# Altium schematic sheet uPre V2.SchDoc("uPre V2")
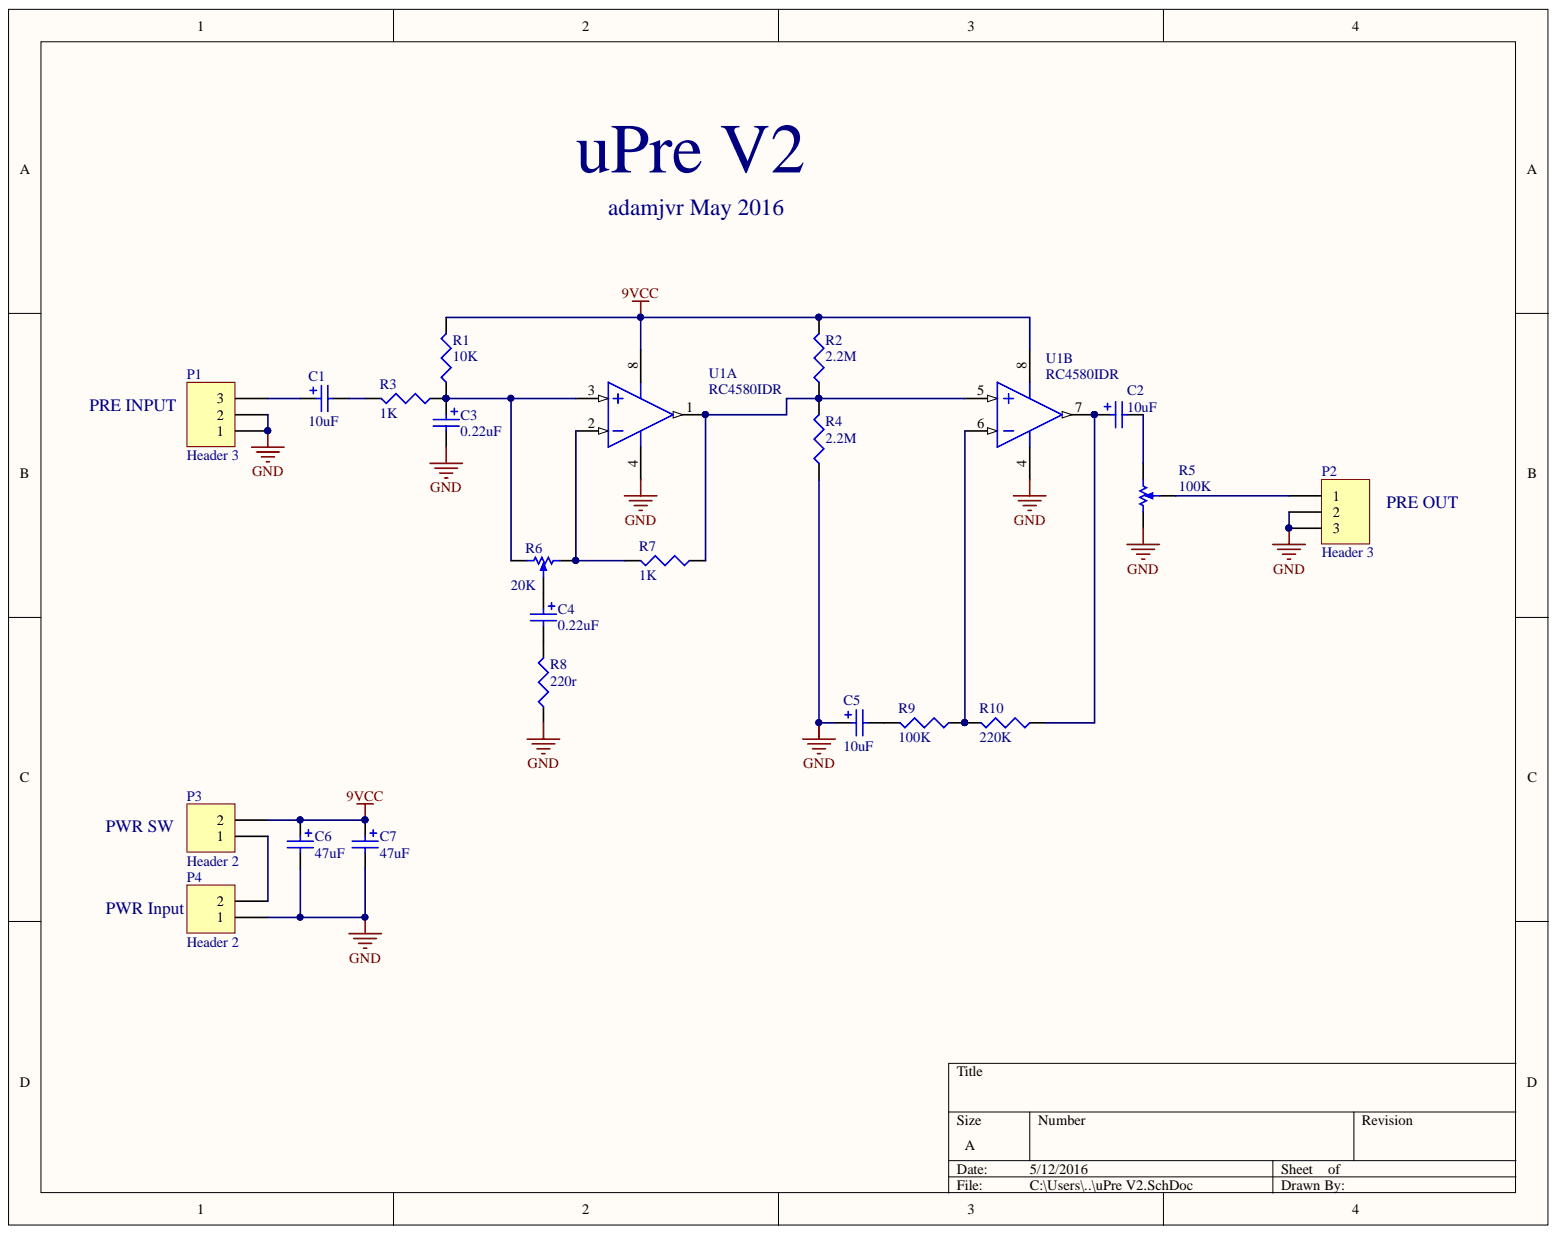
[diagram p0: uPre V2.SchDoc]

## Parts
C1  10uF  [4X6mmCAP]
C2  10uF  [4X6mmCAP]
C3  0.22uF  [4X6mmCAP]
C4  0.22uF  [4X6mmCAP]
C5  10uF  [4X6mmCAP]
C6  47uF  [5X12.5mmCAP - BLUE]
C7  47uF  [5X12.5mmCAP - BLUE]
P1  Header 3  [HDR1X3]
P2  Header 3  [HDR1X3]
P3  Header 2  [HDR1X2]
P4  Header 2  [HDR1X2]
R1  10K  [R0805]
R2  2.2M  [R0805]
R3  1K  [R0805]
R4  2.2M  [R0805]
R5  100K  [BOURNS_PTD90SERIES_POT]
R6  20K  [BOURNS_PTD90SERIES_POT]
R7  1K  [R0805]
R8  220r  [R0805]
R9  100K  [R0805]
R10  220K  [R0805]
U1  RC4580IDR  [SOIC127P600X175-8N]  (parts A,B)

## Nets
9VCC: C6.1 C7.1 P3.2 R1.2 R2.2 U1.8
GND: C3.2 C5.1 C6.2 C7.2 P1.1 P1.2 P2.2 P2.3 P4.1 R4.1 R5.3 R8.1 U1.4
NetC1_1: C1.1 P1.3
NetC1_2: C1.2 R3.2
NetC2_1: C2.1 R10.1 U1.7
NetC2_2: C2.2 R5.1
NetC3_1: C3.1 R1.1 R3.1 R6.3 U1.3
NetC4_1: C4.1 R6.2
NetC4_2: C4.2 R8.2
NetC5_2: C5.2 R9.2
NetP2_1: P2.1 R5.2
NetP3_1: P3.1 P4.2
NetR2_1: R2.1 R4.2 R7.1 U1.1 U1.5
NetR6_1: R6.1 R7.2 U1.2
NetR9_1: R9.1 R10.2 U1.6

## Library data of the parts
C1 C2 C5 = UMW1C100MDD1TP: CAP ALUM 10UF 20% 16V RADIAL; MFR: Nichicon; Designer: Adam Vadala-Roth; Lead-Free ?: Yes; Pin Count #: 2; Type: aluminum capacitor throughhole; Component Kind: Standard; Cost: 0.08766; Datasheet: http://nichicon-us.com/english/products/pdfs/e-umw.pdf; Date Created: 10 May 2016; Height: 6mm; Last Modified: 10 May 2016; Library Name: AudioPartLib.SchLib; MFR #: UMW1C100MDD1TP; Mounting: Through hole; Status: Active; Tolerance: 20%; Value: 10uF; Voltage: 16V
C3 C4 = UMW1HR22MDD1TP: CAP ALUM 0.22UF 20% 50V RADIAL; MFR: Nichicon; Designer: Adam Vadala-Roth; Lead-Free ?: Yes; Pin Count #: 2; Type: aluminum capacitor throughhole; Component Kind: Standard; Cost: 0.08766; Datasheet: http://nichicon-us.com/english/products/pdfs/e-umw.pdf; Date Created: 11 May 2016; Height: 6mm; Last Modified: 11 May 2016; Library Name: AudioPartLib.SchLib; MFR #: UMW1HR22MDD1TP; Mounting: Through hole; Status: Active; Tolerance: 20%; Value: 0.22uF; Voltage: 50V
C6 C7 = UKA1E470MDD1TD: CAP ALUM 47UF 20% 25V RADIAL; MFR: Nichicon; Designer: Adam Vadala-Roth; Lead-Free ?: Yes; Pin Count #: 2; Type: Aluminum Capacitor Radial Through hole; Component Kind: Standard; Cost: 0.11360 @ 2000; Datasheet: http://nichicon-us.com/english/products/pdfs/e-ufg.pdf; Date Created: 11 May 2016; Height: 13mm; Last Modified: 11 May 2016; Library Name: AudioPartLib.SchLib; MFR #: UKA1E470MDD1TD; Mounting: Through Hole; Status: Active; Tolerance: 20%; Value: 47uF; Voltage: 25V
P1 P2 = Header 3: Header, 3-Pin; Component Kind: Standard; LatestRevisionDate: 17-Jul-2002; LatestRevisionNote: Re-released for DXP Platform.; Library Name: Miscellaneous Connectors.IntLib; Signal Integrity: Connector; Publisher: Altium Limited
P3 P4 = Header 2: Header, 2-Pin; Component Kind: Standard; LatestRevisionDate: 17-Jul-2002; LatestRevisionNote: Re-released for DXP Platform.; Library Name: Miscellaneous Connectors.IntLib; Signal Integrity: Connector; Publisher: Altium Limited
R1 = ERJ-6ENF1002V: RES SMD 10K OHM 1% 1/8W 0805; MFR: Panasonic-Electronic-Components; Designer: Adam Vadala-Roth; Lead-Free ?: Yes; Pin Count #: 2; Component Kind: Standard; Cost: 0.00729 @ 1000; Datasheet: http://industrial.panasonic.com/www-cgi/jvcr13pz.cgi?E+PZ+3+AOA0002+ERJ6ENF1002V+7+WW; Date Created: 8 May 2016; Height: 0.7mm; Last Modified: 8 May 2016; Library Name: AudioPartLib.SchLib; MFR #: ERJ-6ENF1002V; Mounting: SMT/SMD; Status: Active; Tolerance: 1%; Type: Chip Resistor 0805; Value: 10K; Voltage: 1/8W
R2 R4 = RC2012F225CS: RES SMD 2.2M OHM 1% 1/8W 0805; MFR: Samsung-Electro-Mechanics-America,-Inc.; Designer: Adam Vadala-Roth; Lead-Free ?: Yes; Pin Count #: 2; Component Kind: Standard; Cost: 0.00289 @ 5000; Datasheet: https://media.digikey.com/pdf/Data%20Sheets/Samsung%20PDFs/RC_Series_ds.pdf; Date Created: 10 May 2016; Height: 0.60mm; Last Modified: 10 May 2016; Library Name: AudioPartLib.SchLib; MFR #: RC2012F225CS; Mounting: SMT/SMD; Status: Active; Tolerance: 1%; Type: Chip Resistor 0805; Value: 2.2M; Voltage: 1/8W
R3 R7 = RC2012F102CS: RES SMD 1K OHM 1% 1/8W 0805; MFR: Samsung-Electro-Mechanics-America,-Inc.; Designer: Adam Vadala-Roth; Lead-Free ?: Yes; Pin Count #: 2; Component Kind: Standard; Cost: 0.00408	 @ 1000; Datasheet: https://media.digikey.com/pdf/Data%20Sheets/Samsung%20PDFs/RC_Series_ds.pdf; Date Created: 10 May 2016; Height: 0.6mm; Last Modified: 10 May 2016; Library Name: AudioPartLib.SchLib; MFR #: RC2012F102CS; Mounting: SMT/SMD; Status: Active; Tolerance: 1%; Type: Chip Resistor; Value: 1K; Voltage: 1/8W
R5 = PTD901-2015K-B104: POT 100K OHM 1/20W CARBON LINEAR; MFR: Bourns Inc.; Designer: Adam Vadala-Roth; Lead-Free ?: Yes; Pin Count #: 3; Tolerance: 20%; Component Kind: Standard; Cost: 0.91800	 @ 1000; Datasheet: http://www.bourns.com/docs/Product-Datasheets/PTD90.pdf; Date Created: 10 May 2016; Height: 11mm; Last Modified: 10 May 2016; Library Name: AudioPartLib.SchLib; MFR #: PTD901-2015K-B104; Mounting: Through Hole; Status: Active; Type: Throughhole Potentiometer; Value: 100K; Voltage: 1/20W
R6 = PTD901-2015K-B203: POT 20K OHM 1/20W CARBON LINEAR; MFR: Bourns Inc.; Designer: Adam Vadala-Roth; Lead-Free ?: Yes; Pin Count #: 3; Tolerance: 20%; Component Kind: Standard; Cost: 0.91800	 @ 1000; Datasheet: http://www.bourns.com/docs/Product-Datasheets/PTD90.pdf; Date Created: 10 May 2016; Height: 11mm; Last Modified: 10 May 2016; Library Name: AudioPartLib.SchLib; MFR #: PTD901-2015K-B203; Mounting: Through Hole; Status: Active; Type: Throughhole Potentiometer; Value: 20K; Voltage: 1/20W
R8 = RC2012F221CS: RES SMD 220 OHM 1% 1/8W 0805; MFR: Samsung-Electro-Mechanics-America,-Inc.; Designer: Adam Vadala-Roth; Lead-Free ?: Yes; Pin Count #: 2; Tolerance: 5%; Component Kind: Standard; Cost: 0.00408	 @ 1000; Datasheet: https://media.digikey.com/pdf/Data%20Sheets/Samsung%20PDFs/RC_Series_ds.pdf; Date Created: 11 May 2016; Height: 0.60mm; Last Modified: 11 May 2016; Library Name: AudioPartLib.SchLib; MFR #: RC2012F221CS; Mounting: SMT/SMD; Status: Active; Type: Chip Resistor 0805; Value: 220r; Voltage: 1/8W
R9 = ERJ-6ENF1003V: RES SMD 100K OHM 1% 1/8W 0805; MFR: Panasonic-Electronic-Components; Designer: Adam Vadala-Roth; Lead-Free ?: Yes; Pin Count #: 2; Component Kind: Standard; Cost: 0.00729 @ 1000; Datasheet: http://industrial.panasonic.com/www-cgi/jvcr13pz.cgi?E+PZ+3+AOA0002+ERJ6ENF1003V+7+WW; Date Created: 9 May 2016; Height: 0.7mm; Last Modified: 9 May 2016; Library Name: AudioPartLib.SchLib; MFR #: ERJ-6ENF1003V; Mounting: SMT/SMD; Status: Active; Tolerance: 1%; Type: Chip Resistor; Value: 100K; Voltage: 1/8W
R10 = RC2012F224CS: RES SMD 220K OHM 1% 1/8W 0805; MFR: Samsung-Electro-Mechanics-America,-Inc.; Designer: Adam Vadala-Roth; Lead-Free ?: Yes; Pin Count #: 2; Component Kind: Standard; Cost: 0.00266 @ 5000; Datasheet: https://media.digikey.com/pdf/Data%20Sheets/Samsung%20PDFs/RC_Series_ds.pdf; Date Created: 11 May 2016; Height: 0.60mm; Last Modified: 11 May 2016; Library Name: AudioPartLib.SchLib; MFR #: RC2012F224CS; Mounting: SMT/SMD; Status: Active; Tolerance: 1%; Type: Chip Resistor 0805; Value: 220K; Voltage: 1/8W
U1 = RC4580IDR: IC OPAMP AUDIO 12MHZ 8SOIC Low Noise, High Performance, High Fidelity Dual Audio Operational Amplifier, 8-pin Narrow SOIC; MFR: Texas Instruments; Designer: Adam Vadala-Roth; Lead-Free ?: Yes; Pin Count #: 8; Voltage: 16V Max; Component Kind: Standard; Cost: 0.35000 @ 2500; Datasheet: http://www.ti.com/lit/ds/symlink/rc4580.pdf; Date Created: 10 May 2016; Height: 1.2mm; Last Modified: 10 May 2016; Library Name: AudioPartLib.SchLib; MFR #: RC4580IDR; Mounting: SMT/SMD; Status: Active; Tolerance: N/A; Type: OPAMP IC, SOIC8 Package
